# Altium schematic sheet main_Schematic.SchDoc(main_Schematic)
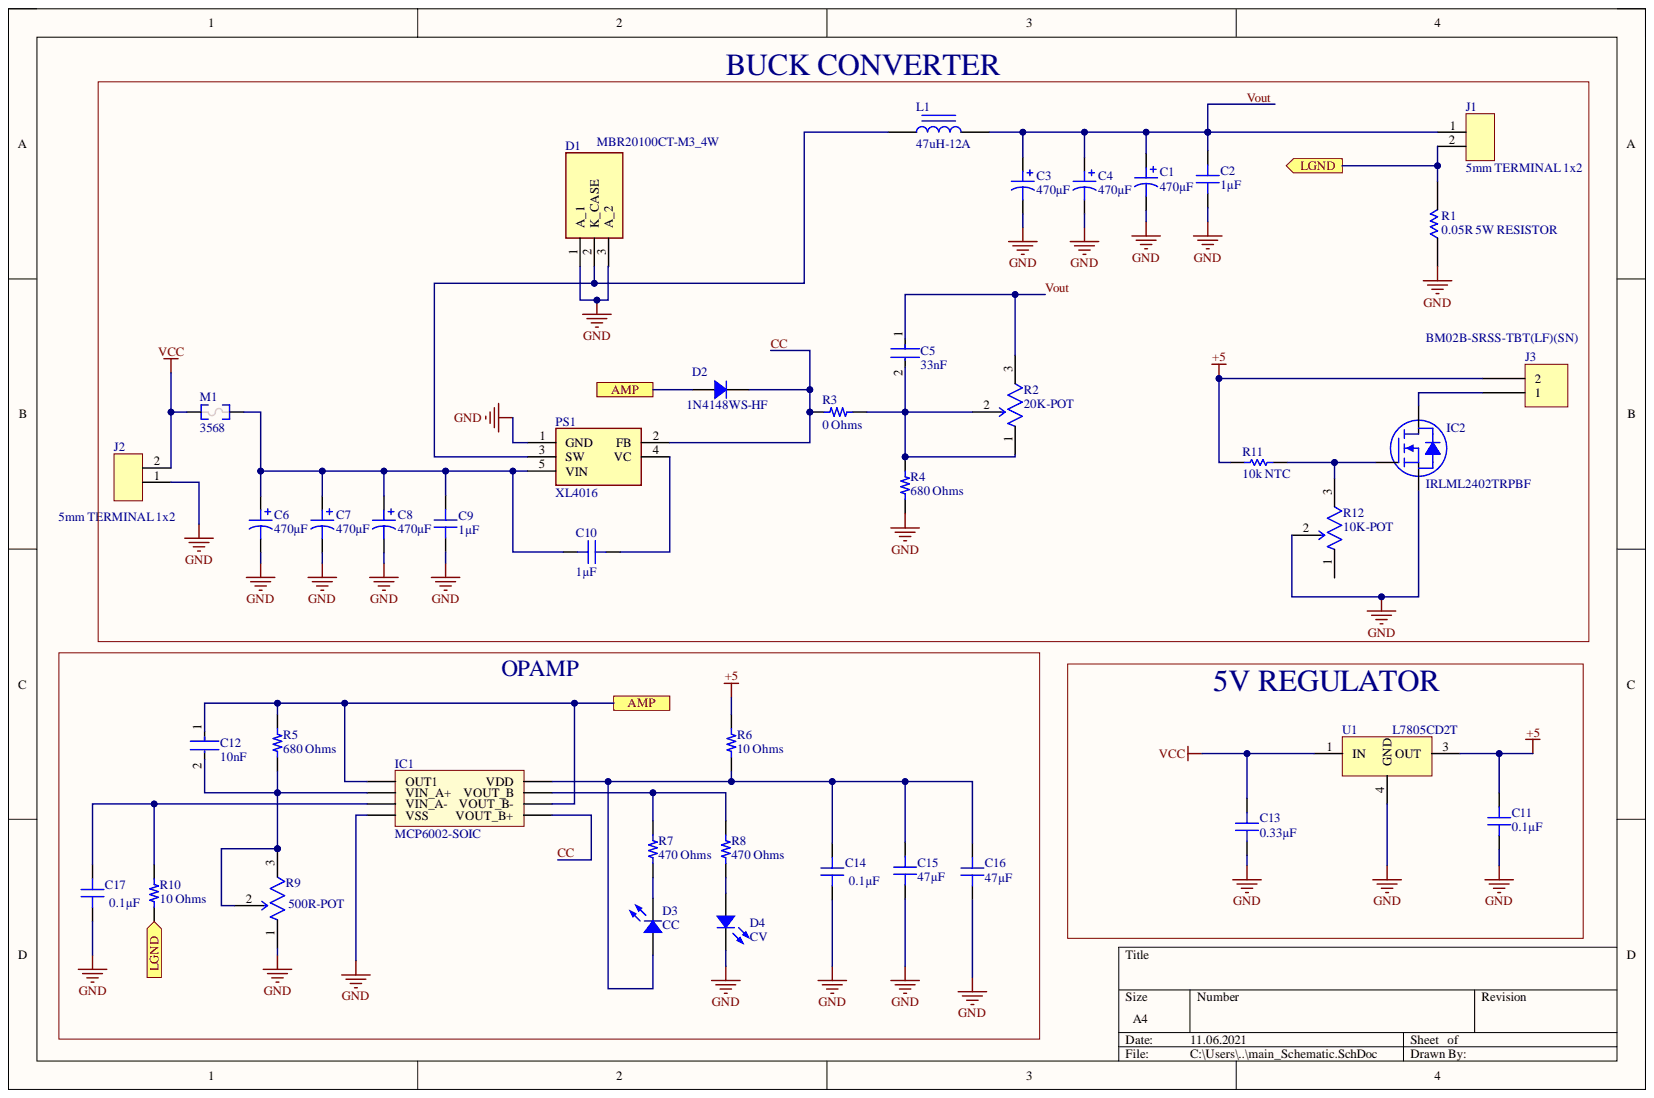
[diagram p0: main_Schematic.SchDoc]

## Parts
C1  470µF  [CAP TH ALUM ELEC D10.00mm S5.00mm H21.50mm]
C2  1µF  [CAP 1206_3216 - 1.2MM]
C3  470µF  [CAP TH ALUM ELEC D10.00mm S5.00mm H21.50mm]
C4  470µF  [CAP TH ALUM ELEC D10.00mm S5.00mm H21.50mm]
C5  33nF  [FP-C1206C-EB-MFG]
C6  470µF  [CAP TH ALUM ELEC D10.00mm S5.00mm H21.50mm]
C7  470µF  [CAP TH ALUM ELEC D10.00mm S5.00mm H21.50mm]
C8  470µF  [CAP TH ALUM ELEC D10.00mm S5.00mm H21.50mm]
C9  1µF  [CAP 1206_3216 - 1.2MM]
C10  1µF  [CAP 1206_3216 - 1.2MM]
C11  0.1µF  [CAP 1206_3216 - 1.0MM]
C12  10nF  [FP-C1206C-EB-MFG]
C13  0.33µF  [CAP 1206_3216 - 1.8MM]
C14  0.1µF  [CAP 1206_3216 - 1.0MM]
C15  47µF  [CAP 1206_3216 - 1.8MM]
C16  47µF  [CAP 1206_3216 - 1.8MM]
C17  0.1µF  [CAP 1206_3216 - 1.0MM]
D1  MBR20100CT-M3_4W  [TO255P470X1010X1989-3P]
D2  1N4148WS-HF  [SOD323]
D3  CC  [LED 1206_3216 RED]
D4  CV  [LED 1206_3216 GREEN]
IC1  MCP6002-SOIC  [SOIC127P600X175-8N]
IC2  IRLML2402TRPBF  [INFINEON SOT-23-3]
J1  5mm TERMINAL 1x2  [5mm TERMINAL 1x2]
J2  5mm TERMINAL 1x2  [5mm TERMINAL 1x2]
J3  BM02B-SRSS-TBT(LF)(SN)  [JST BM02B-SRSS-TB]
L1  47uH-12A  [47uH-12A]
M1  3568  [KEYSTONE 3568]
PS1  XL4016  [XL4016]
R1  0.05R 5W RESISTOR  [0.1R 5W RESISTOR]
R2  20K-POT  [FP-3296W-MFG]
R3  0 Ohms  [RES 1206_3216]
R4  680 Ohms  [RES 1206_3216]
R5  680 Ohms  [RES 1206_3216]
R6  10 Ohms  [RES 1206_3216]
R7  470 Ohms  [RES 1206_3216]
R8  470 Ohms  [RES 1206_3216]
R9  500R-POT  [FP-3296W-MFG]
R10  10 Ohms  [RES 1206_3216]
R11  10k NTC  [RESC3216X180X46NL20T20]
R12  10K-POT  [FP-3296W-MFG]
U1  L7805CD2T  [DPAK228P1085X240-3N]

## Ports
AMP, LGND

## Nets
+5: C11.2 J3.2 R6.2 R11.1 U1.3
GND: C1.2 C2.1 C3.2 C4.2 C6.2 C7.2 C8.2 C9.1 C11.1 C13.1 C14.1 C15.1 C16.1 C17.1 D1.1 D1.3 D4.2 IC1.4(VSS) IC2.2 J2.1 PS1.1(GND) R1.1 R4.1 R9.1 R12.2 U1.4
VCC: C13.2 J2.2 M1.1 U1.1
AMP: C12.1 D2.1 IC1.1(OUT1) IC1.6(VOUT_B-) R5.2 ; port AMP
CC: D2.2 IC1.5(VOUT_B+) PS1.2(FB) R3.2
LGND: J1.2 R1.2 R10.1 ; port LGND
Vout: C1.1 C2.2 C3.1 C4.1 C5.1 J1.1 L1.2 R2.3
NetC5_2: C5.2 R2.1 R2.2 R3.1 R4.2
NetC6_1: C6.1 C7.1 C8.1 C9.2 C10.1 M1.2 PS1.5(VIN)
NetC10_2: C10.2 PS1.4(VC)
NetC12_2: C12.2 IC1.2(VIN_A+) R5.1 R9.2 R9.3
NetC14_2: C14.2 C15.2 C16.2 D3.1 IC1.8(VDD) R6.1
NetC17_2: C17.2 IC1.3(VIN_A-) R10.2
NetD1_2: D1.2 L1.1 PS1.3(SW)
NetD3_2: D3.2 R7.1
NetD4_1: D4.1 R8.1
NetJ3_1: IC2.3 J3.1
NetR7_2: IC1.7(VOUT_B) R7.2 R8.2
NetR11_2: IC2.1 R11.2 R12.3

Not connected: R12 1

## Library data of the parts
C1 C3 C4 C6-C8 = ESMG500ELL471MJ20S: CAP ALUM 470UF 20% 50V RADIAL; Manufacturer: United Chemi-Con; Database Table Name: Capacitors - Aluminum - Electrolytic TH; Lifetime @ Temp.: 2000 Hrs @ 85°C; Polarization: Polar; Ripple Current @ High Frequency: 1.1325A @ 100kHz; Ripple Current @ Low Frequency: 755mA @ 120Hz; Applications: General Purpose; Capacitance: 470µF; Component Kind: Standard; ComponentLink1Description: Datasheet; ComponentLink1URL: http://www.chemi-con.co.jp/cgi-bin/CAT_DB/SEARCH/cat_db_al.cgi?e=e&j=p&pdfname=smg; ComponentLink2Description: DigiKey Link; ComponentLink2URL: http://digikey.com/product-detail/en/united-chemi-con/ESMG500ELL471MJ20S/565-1113-ND/755629; Device Package: Radial, Can; Height - Seated (Max): 0.846" (21.50mm); LastUpdated: 2.09.2020 01:31:34; Lead Spacing: 0.197" (5.00mm); Library Name: Celestial Altium Library - altium_library.DbLib; Lifecycle Status: Active; Minimum Order: 1; Mounting Type: Through Hole; Operating Temperature: -40°C ~ 85°C; Package / Case: Radial, Can; Packaging: Bulk; Part Number: ESMG500ELL471MJ20S; Part Status: Active; PartId: 12556; Price: 0.77; Series: SMG; Size / Dimension: 0.394" Dia (10.00mm); Supplier 1: DigiKey; Supplier Part Number 1: 565-1113-ND; Tolerance: ±20%; Value: 470µF; Voltage - Rated: 50V
C2 C9 C10 = C1206C105M5RACTU: CAP CER 1UF 50V X7R 1206; Manufacturer: KEMET; Database Table Name: Capacitors - Ceramic - 1206; Tolerance: ±20%; Applications: Bypass, Decoupling; Capacitance: 1µF; Component Kind: Standard; ComponentLink1Description: Datasheet; ComponentLink1URL: https://api.kemet.com/component-edge/download/datasheet/C1206C105M5RACTU.pdf; ComponentLink2Description: DigiKey Link; ComponentLink2URL: http://digikey.com/product-detail/en/kemet/C1206C105M5RACTU/399-8151-1-ND/3471874; Device Package: 1206 (3216 Metric); LastUpdated: 2.09.2020 01:05:10; Library Name: Celestial Altium Library - altium_library.DbLib; Lifecycle Status: Active; Minimum Order: 1; Mounting Type: Surface Mount, MLCC; Operating Temperature: -55°C ~ 125°C; Package / Case: 1206 (3216 Metric); Packaging: TapeAndReel; Part Number: C1206C105M5RACTU; Part Status: Active; PartId: 18886; Price: 0.07; Series: SMD Comm X7R; Size / Dimension: 0.126" L x 0.063" W (3.20mm x 1.60mm); Supplier 1: DigiKey; Supplier Part Number 1: 399-8151-1-ND; Temperature Coefficient: X7R; Thickness (Max): 0.043" (1.10mm); Value: 1µF; Voltage - Rated: 50V
C5 = CMP-03020-013789-1: Multilayer Ceramic Capacitor, C Series, 0.033 - F, - 10%, X7r, 50 V, 1206 [3216 Metric]; Manufacturer: KEMET; Manufacturer Part Number: C1206C333K5RACTU; Automotive Grade: Grade 0; Capacitance: 33,000 pF; Temperature Coefficient: 15%; Tolerance: 0.1; Value: 33,000 pF; Voltage Rating: 50 V; Automotive: Yes; Category: Cap; Component Kind: Standard; ComponentLink2Description: Datasheet; ComponentLink2URL: https://content.kemet.com/datasheets/KEM_C1007_X8R_ULTRA_150C_SMD.pdf; Device Class L1: Passive Components; Device Class L2: Capacitors; Device Class L3: Ceramic Capacitors; Digikey Description: Multilayer Ceramic Capacitor, C Series, 0.033 - F, - 10%, X7r, 50 V, 1206 [3216 Metric]; Height: 0.88mm; Lead Free: Yes; Library Name: Altium Content Vault; Material: Ceramic; Mouser Description: Multilayer Ceramic Capacitor, C Series, 0.033 - F, - 10%, X7r, 50 V, 1206 [3216 Metric]; Octopart: https://octopart.com/c1206c333k5ractu-kemet-125953; Package: C1206C-EB; RoHS: TRUE; Temperature: -55°C to +150°C; Temperature Characteristic: X7R; Temperature Range High: +150°C; Temperature Range Low: -55°C; Ipc Land Pattern Name: CAPC320160X78
C11 = CC1206KRX7R7BB104: CAP CER 0.1UF 16V X7R 1206; Manufacturer: Yageo; Database Table Name: Capacitors - Ceramic - 1206; Tolerance: ±10%; Applications: General Purpose; Capacitance: 0.1µF; Component Kind: Standard; ComponentLink1Description: Datasheet; ComponentLink1URL: https://www.yageo.com/upload/media/product/productsearch/datasheet/mlcc/UPY-GPHC_X7R_6.3V-to-50V_18.pdf; ComponentLink2Description: DigiKey Link; ComponentLink2URL: http://digikey.com/product-detail/en/yageo/CC1206KRX7R7BB104/311-4482-1-ND/8025571; Device Package: 1206 (3216 Metric); LastUpdated: 2.09.2020 01:04:53; Library Name: Celestial Altium Library - altium_library.DbLib; Lifecycle Status: Active; Minimum Order: 1; Mounting Type: Surface Mount, MLCC; Operating Temperature: -55°C ~ 125°C; Package / Case: 1206 (3216 Metric); Packaging: TapeAndReel; Part Number: CC1206KRX7R7BB104; Part Status: Active; PartId: 21447; Price: 0.03; Series: CC; Size / Dimension: 0.126" L x 0.063" W (3.20mm x 1.60mm); Supplier 1: DigiKey; Supplier Part Number 1: 311-4482-1-ND; Temperature Coefficient: X7R; Thickness (Max): 0.037" (0.95mm); Value: 0.1µF; Voltage - Rated: 16V
C12 = CMP-2000-06008-2: CAP CER 10000PF 50V X7R 1206; Manufacturer: KEMET; Manufacturer Part Number: C1206C103K5RACTU; Automotive Grade: Grade 0; Capacitance: 10,000 pF; Material: Ceramic; Temperature Coefficient: 15%; Tolerance: 0.1; Value: 10,000 pF; Automotive: Yes; Category: Cap; Component Kind: Standard; ComponentLink2Description: Datasheet; ComponentLink2URL: https://content.kemet.com/datasheets/KEM_C1007_X8R_ULTRA_150C_SMD.pdf; Device Class L1: Passive Components; Device Class L2: Capacitors; Device Class L3: Ceramic Capacitors; Digikey Description: CAP CER 10000PF 50V X7R 1206; Height: 0.88mm; Lead Free: Yes; Library Name: Altium Content Vault; Mouser Description: Multilayer Ceramic Capacitors MLCC - SMD/SMT 50volts 10000pF X7R 10%; Octopart: https://octopart.com/c1206c103k5ractu-kemet-130245; Package: C1206C-EB; RoHS: TRUE; Temperature: -55°C to +150°C; Temperature Characteristic: X7R; Temperature Range High: +150°C; Temperature Range Low: -55°C; Voltage Rating: 50 V; Ipc Land Pattern Name: CAPC320160X78
C13 = CL31B334KBHWPNE: CAP CER 0.33UF 50V X7R 1206; Manufacturer: Samsung Electro-Mechanics; Database Table Name: Capacitors - Ceramic - 1206; Tolerance: ±10%; Applications: Automotive; Capacitance: 0.33µF; Component Kind: Standard; ComponentLink1Description: Datasheet; ComponentLink1URL: http://www.samsungsem.com/kr/support/product-search/mlcc/CL31B334KBHWPNE.jsp; ComponentLink2Description: DigiKey Link; ComponentLink2URL: http://digikey.com/product-detail/en/samsung-electro-mechanics/CL31B334KBHWPNE/1276-6674-1-ND/5961533; Device Package: 1206 (3216 Metric); Features: Open Mode; LastUpdated: 2.09.2020 01:05:22; Library Name: Celestial Altium Library - altium_library.DbLib; Lifecycle Status: Active; Minimum Order: 1; Mounting Type: Surface Mount, MLCC; Operating Temperature: -55°C ~ 125°C; Package / Case: 1206 (3216 Metric); Packaging: TapeAndReel; Part Number: CL31B334KBHWPNE; Part Status: Active; PartId: 22736; Price: 0.08; Ratings: AEC-Q200; Series: CL; Size / Dimension: 0.126" L x 0.063" W (3.20mm x 1.60mm); Supplier 1: DigiKey; Supplier Part Number 1: 1276-6674-1-ND; Temperature Coefficient: X7R; Thickness (Max): 0.071" (1.80mm); Value: 0.33µF; Voltage - Rated: 50V
C14 C17 = C1206C104K8RAC7800: CAP CER 0.1UF 10V X7R 1206; Manufacturer: KEMET; Database Table Name: Capacitors - Ceramic - 1206; Tolerance: ±10%; Applications: Bypass, Decoupling; Capacitance: 0.1µF; Component Kind: Standard; ComponentLink1Description: Datasheet; ComponentLink1URL: https://api.kemet.com/component-edge/download/datasheet/C1206C104K8RACTU.pdf; ComponentLink2Description: DigiKey Link; ComponentLink2URL: http://digikey.com/product-detail/en/kemet/C1206C104K8RAC7800/399-15539-1-ND/7386937; Device Package: 1206 (3216 Metric); LastUpdated: 2.09.2020 01:05:04; Library Name: Celestial Altium Library - altium_library.DbLib; Lifecycle Status: Active; Minimum Order: 1; Mounting Type: Surface Mount, MLCC; Operating Temperature: -55°C ~ 125°C; Package / Case: 1206 (3216 Metric); Packaging: TapeAndReel; Part Number: C1206C104K8RAC7800; Part Status: Active; PartId: 19429; Price: 0.10; Series: SMD Comm X7R; Size / Dimension: 0.126" L x 0.063" W (3.20mm x 1.60mm); Supplier 1: DigiKey; Supplier Part Number 1: 399-15539-1-ND; Temperature Coefficient: X7R; Thickness (Max): 0.035" (0.88mm); Value: 0.1µF; Voltage - Rated: 10V
C15 C16 = CL31A476MPHNNNE: CAP CER 47UF 10V X5R 1206; Manufacturer: Samsung Electro-Mechanics; Database Table Name: Capacitors - Ceramic - 1206; Tolerance: ±20%; Applications: General Purpose; Capacitance: 47µF; Component Kind: Standard; ComponentLink1Description: Datasheet; ComponentLink1URL: http://www.samsungsem.com/kr/support/product-search/mlcc/CL31A476MPHNNNE.jsp; ComponentLink2Description: DigiKey Link; ComponentLink2URL: http://digikey.com/product-detail/en/samsung-electro-mechanics/CL31A476MPHNNNE/1276-3063-1-ND/3891149; Device Package: 1206 (3216 Metric); LastUpdated: 2.09.2020 01:05:28; Library Name: Celestial Altium Library - altium_library.DbLib; Lifecycle Status: Active; Minimum Order: 1; Mounting Type: Surface Mount, MLCC; Operating Temperature: -55°C ~ 85°C; Package / Case: 1206 (3216 Metric); Packaging: TapeAndReel; Part Number: CL31A476MPHNNNE; Part Status: Active; PartId: 22191; Price: 0.16; Series: CL; Size / Dimension: 0.126" L x 0.063" W (3.20mm x 1.60mm); Supplier 1: DigiKey; Supplier Part Number 1: 1276-3063-1-ND; Temperature Coefficient: X5R; Thickness (Max): 0.071" (1.80mm); Value: 47µF; Voltage - Rated: 10V
D1 = MBR20100CT-M3_4W: Schottky Diode; Manufacturer_Name: Vishay; Manufacturer_Part_Number: MBR20100CT-M3/4W; Arrow Part Number: MBR20100CT-M3/4W; Arrow Price/Stock: https://www.arrow.com/en/products/mbr20100ct-m34w/vishay; Component Kind: Standard; Datasheet Link: https://www.vishay.com/docs/89192/mbr20100.pdf; Height: 4.7mm; Mouser Part Number: 78-MBR20100CT-M3/4W; Mouser Price/Stock: https://www.mouser.co.uk/ProductDetail/Vishay-General-Semiconductor/MBR20100CT-M3-4W?qs=QA6fHpkHtKt1A8Yyuwe6pA%3D%3D
D2 = 1N4148WS-HF: DIODE SWITCHING 100V 150MA 200MW; Manufacturer: Comchip Technology; Capacitance @ Vr, F: 2pF @ 0V, 1MHz; Current - Reverse Leakage @ Vr: 1µA @ 75V; Database Table Name: Diodes - Rectifiers - Single; Diode Type: Standard; Reverse Recovery Time (trr): 4ns; Speed: Fast Recovery =< 500ns, > 200mA (Io); Voltage - DC Reverse (Vr) (Max): 75V; Voltage - Forward (Vf) (Max) @ If: 1.25V @ 150mA; Component Kind: Standard; ComponentLink2Description: DigiKey Link; ComponentLink2URL: http://digikey.com/product-detail/en/comchip-technology/1N4148WS-HF/641-1N4148WS-HFCT-ND/12326327; Current - Average Rectified (Io): 150mA; LastUpdated: 30.08.2020 21:07:00; Library Name: Celestial Altium Library - altium_library.DbLib; Lifecycle Status: Active; Minimum Order: 1; Mounting Type: Surface Mount; Operating Temperature - Junction: 125°C; Package / Case: SC-76, SOD-323; Packaging: Unknown; Part Number: 1N4148WS-HF; PartId: 3368; Price: 0.02; Supplier 1: DigiKey; Supplier Device Package: SOD-323; Supplier Part Number 1: 641-1N4148WS-HFCT-ND
D3 = CMD11-21VRC/TR8: LED RED CLEAR 1206 SMD; Manufacturer: Visual Communications Company - VCC; Configuration: Standard; Current - Test: 20mA; Database Table Name: LED - Indication; Lens Color: 1.50mm; Lens Size: 1.10mm Dia; Lens Style: Round with Flat Top; Millicandela Rating: 6mcd; Viewing Angle: 20°; Voltage - Forward (Vf) (Typ): 2V; Wavelength - Peak: 640nm; Color: Red; Component Kind: Standard; ComponentLink1Description: Datasheet; ComponentLink1URL: https://vcclite.com/wp-content/uploads/wpallimport/files/files/CMD11-21%20SMT%20LEDs%201206%20Package%20Size.pdf; ComponentLink2Description: DigiKey Link; ComponentLink2URL: http://digikey.com/product-detail/en/visual-communications-company-vcc/CMD11-21VRC-TR8/L62001CT-ND/254910; Device Package: 1206 (3216 Metric); Height (Max): 1.50mm; LastUpdated: 2.09.2020 01:21:09; Lens Transparency: Clear; Library Name: Celestial Altium Library - altium_library.DbLib; Lifecycle Status: Active; Minimum Order: 1; Mounting Type: Surface Mount; Package / Case: 1206 (3216 Metric); Packaging: TapeAndReel; Part Number: CMD11-21VRC/TR8; Part Status: Active; PartId: 184; Price: 0.12; Series: -; Size / Dimension: 3.00mm L x 1.50mm W; Supplier 1: DigiKey; Supplier Device Package: 1206; Supplier Part Number 1: L62001CT-ND; Value: Red
D4 = XZDG55W-3: LED GREEN CLEAR CHIP SMD; Manufacturer: SunLED; Configuration: Standard; Current - Test: 20mA; Database Table Name: LED - Indication; Lens Color: Colorless; Lens Size: 1.60mm Dia; Lens Style: Round with Domed Top; Millicandela Rating: 2290mcd; Viewing Angle: 35°; Voltage - Forward (Vf) (Typ): 3.3V; Wavelength - Dominant: 525nm; Wavelength - Peak: 515nm; Color: Green; Component Kind: Standard; ComponentLink1Description: Datasheet; ComponentLink1URL: https://www.sunledusa.com/products/spec/XZDG55W-3.pdf; ComponentLink2Description: DigiKey Link; ComponentLink2URL: http://digikey.com/product-detail/en/sunled/XZDG55W-3/1497-1127-1-ND/4745593; Device Package: 1206 (3216 Metric); Height (Max): 1.80mm; LastUpdated: 2.09.2020 01:20:47; Lens Transparency: Clear; Library Name: Celestial Altium Library - altium_library.DbLib; Lifecycle Status: Active; Minimum Order: 1; Mounting Type: Surface Mount; Package / Case: 1206 (3216 Metric); Packaging: TapeAndReel; Part Number: XZDG55W-3; Part Status: Active; PartId: 525; Price: 0.18; Series: -; Size / Dimension: 3.20mm L x 1.60mm W; Supplier 1: DigiKey; Supplier Device Package: Chip LED; Supplier Part Number 1: 1497-1127-1-ND; Value: Green
IC1 = MCP6002-SOIC: MCP6002-SOIC OPAMP; Component Kind: Standard; Library Name: Schlib1.SchLib
IC2 = IRLML2402TRPBF: MOSFET N-CH 20V 1.2A SOT-23; Manufacturer: Infineon Technologies; Current - Continuous Drain (Id) @ 25°C: 1.2A (Ta); Database Table Name: MOSFET - Single - N-Ch; Drive Voltage (Max Rds On,  Min Rds On): 2.7V, 4.5V; FET Type: N-Channel; Gate Charge (Qg) (Max) @ Vgs: 3.9nC @ 4.5V; Power Dissipation (Max): 540mW (Ta); Rds On (Max) @ Id, Vgs: 250mOhm @ 930mA, 4.5V; Vgs (Max): ±12V; Vgs(th) (Max) @ Id: 700mV @ 250µA; Input Capacitance (Ciss) (Max) @ Vds: 110pF @ 15V; Component Kind: Standard; ComponentLink1Description: Datasheet; ComponentLink1URL: https://www.infineon.com/dgdl/irlml2402pbf.pdf?fileId=5546d462533600a401535664e5ef25fa; ComponentLink2Description: DigiKey Link; ComponentLink2URL: http://digikey.com/product-detail/en/infineon-technologies/IRLML2402TRPBF/IRLML2402PBFCT-ND/812508; Drain to Source Voltage (Vdss): 20V; LastUpdated: 2.09.2020 01:25:05; Library Name: Celestial Altium Library - altium_library.DbLib; Lifecycle Status: Active; Minimum Order: 1; Mounting Type: Surface Mount; Operating Temperature: -55°C ~ 150°C (TJ); Package / Case: TO-236-3, SC-59, SOT-23-3; Packaging: TapeAndReel; Part Number: IRLML2402TRPBF; Part Status: Active; Price: 0.10; Series: HEXFET®; Supplier 1: DigiKey; Supplier Device Package: Micro3™/SOT-23; Supplier Part Number 1: IRLML2402PBFCT-ND; Technology: MOSFET (Metal Oxide)
J3 = BM02B-SRSS-TBT(LF)(SN): CONN HEADER SMD 2POS 1MM; Manufacturer: JST Sales America Inc.; Current Rating (Amps): 0.7A; Database Table Name: Connectors - Rectangular Headers Male Pins; Material Flammability Rating: UL94 V-0; Number of Positions: 2; Number of Positions Loaded: All; Pitch - Mating: 0.039" (1.00mm); Shrouding: Shrouded - 4 Wall; Style: Board to Cable/Wire; Voltage Rating: 50V; Component Kind: Standard; ComponentLink1Description: Datasheet; ComponentLink1URL: http://www.jst-mfg.com/product/pdf/eng/eSR.pdf; ComponentLink2Description: DigiKey Link; ComponentLink2URL: http://digikey.com/product-detail/en/jst-sales-america-inc/BM02B-SRSS-TBT-LF-SN/455-2955-1-ND/5725846; Connector Type: Header; Contact Finish - Mating: Tin; Contact Finish - Post: Tin; Contact Material: Copper Alloy; Contact Shape: Square; Contact Type: Male Pin; Fastening Type: Push-Pull; Features: Pick and Place, Solder Retention; LastUpdated: 12.01.2020 22:11:16; Library Name: Celestial Altium Library - altium_library.DbLib; Lifecycle Status: Active; Minimum Order: 1; Mounting Type: Surface Mount; Number of Rows: 1; Operating Temperature: -25°C ~ 85°C; Packaging: TapeAndReel; Part Number: BM02B-SRSS-TBT(LF)(SN); Part Status: Active; PartId: 13; Price: 0.31; Series: SR; Supplier 1: DigiKey; Supplier Part Number 1: 455-2955-1-ND; Termination: Solder; Insulation Color: Natural; Insulation Height: 0.167" (4.25mm); Insulation Material: Polyamide (PA), Nylon
L1 = 47uH-12A: 47uH-12A Power Inductor; Component Kind: Standard; Library Name: Schlib1.SchLib
M1 = 3568: FUSE HOLDER BLADE 500V 30A PCB; Manufacturer: Keystone Electronics; Database Table Name: Fuses - Fuseholders; For Use With/Related Products: MINI ATC, MINI ATO; Fuse Size: 0.43" L x 0.15" W x 0.347" H (10.92mm x 3.81mm x 8.81mm); Number of Circuits: 1; Component Kind: Standard; ComponentLink1Description: Datasheet; ComponentLink1URL: http://keyelco.com/userAssets/file/M65p42.pdf; ComponentLink2Description: DigiKey Link; ComponentLink2URL: http://digikey.com/product-detail/en/keystone-electronics/3568/36-3568-ND/2137306; Contact Finish: Tin; Contact Material: Brass; Current Rating (Amps): 30A; Fuse Type: Blade; Fuseholder Type: Holder; LastUpdated: 5.04.2020 19:02:49; Library Name: Celestial Altium Library - altium_library.DbLib; Lifecycle Status: Active; Minimum Order: 1; Mounting Type: Through Hole; Orientation: Vertical; Packaging: Unknown; Part Number: 3568; Part Status: Active; Price: 1.03; Series: MINI® 297; Supplier 1: DigiKey; Supplier Part Number 1: 36-3568-ND; Termination Style: PC Pin; Voltage: 500V
PS1 = XL4016: Power Supply; Manufacturer_Name: XLSEMI; Component Kind: Standard; Datasheet Link: http://www.xlsemi.com/datasheet/xl4016%20datasheet.pdf; Height: 15.49mm; Library Name: SamacSys.SchLib; Manufacturer_Part_Number: XL4016
R1 = 0.1R 5W RESISTOR: 0.1R 5W RESISTOR STONE; Component Kind: Standard; Library Name: Schlib1.SchLib
R2 R9 R12 = CMP-07234-000024-1: TRIMMER 10K OHM 0.5W PC PIN TOP; Max Power Dissipation: 500mW; Number of Turns: 25; Resistance: 10kR; Temperature Coefficient: 100ppm/°C; Tolerance: 10%; Component Kind: Standard; Composition: Cermet; Contact Plating: Tin; Depth: 4.83mm; Height: 0.395inch; Length: 9.53mm; Library Name: Altium Content Vault; Max Operating Temperature: 125°C; Military Standard: Not; Min Operating Temperature: -55°C; Mount: Through Hole; Package Quantity: 1; Packaging: Tape and Reel; Power Rating: 500mW; Radiation Hardening: No; REACH SVHC: No SVHC; Taper: Linear; Termination: Pin; Weight: 0.03oz; Width: 0.19inch
R3 = RC1206JR-070RL: RES SMD 0 OHM JUMPER 1/4W 1206; Manufacturer: Yageo; Database Table Name: Resistors - Surface Mount - 1206; Power (Watts): 0.25W, 1/4W; Component Kind: Standard; ComponentLink1Description: Datasheet; ComponentLink1URL: https://www.yageo.com/upload/media/product/productsearch/datasheet/rchip/PYu-RC_Group_51_RoHS_L_10.pdf; ComponentLink2Description: DigiKey Link; ComponentLink2URL: http://digikey.com/product-detail/en/yageo/RC1206JR-070RL/311-0.0ERCT-ND/732131; Composition: Thick Film; Device Package: 1206 (3216 Metric); Features: Moisture Resistant; Height - Seated (Max): 0.026" (0.65mm); LastUpdated: 2.09.2020 01:12:40; Library Name: Celestial Altium Library - altium_library.DbLib; Lifecycle Status: Active; Minimum Order: 1; Number of Terminations: 2; Operating Temperature: -55°C ~ 155°C; Package / Case: 1206 (3216 Metric); Packaging: TapeAndReel; Part Number: RC1206JR-070RL; Part Status: Active; PartId: 39273; Price: 0.01; Resistance: 0 Ohms; Series: RC; Size / Dimension: 0.122" L x 0.063" W (3.10mm x 1.60mm); Supplier 1: DigiKey; Supplier Device Package: 1206; Supplier Part Number 1: 311-0.0ERCT-ND; Tolerance: Jumper; Value: 0 Ohms
R4 R5 = CR1206-JW-681ELF: RES SMD 680 OHM 5% 1/4W 1206; Manufacturer: Bourns Inc.; Database Table Name: Resistors - Surface Mount - 1206; Power (Watts): 0.25W, 1/4W; Temperature Coefficient: ±200ppm/°C; Component Kind: Standard; ComponentLink1Description: Datasheet; ComponentLink1URL: https://www.bourns.com/docs/Product-Datasheets/CHPREZTR.pdf; ComponentLink2Description: DigiKey Link; ComponentLink2URL: http://digikey.com/product-detail/en/bourns-inc/CR1206-JW-681ELF/CR1206-JW-681ELF-ND/3785655; Composition: Thick Film; Device Package: 1206 (3216 Metric); Features: Moisture Resistant; LastUpdated: 2.09.2020 01:12:22; Library Name: Celestial Altium Library - altium_library.DbLib; Lifecycle Status: Active; Minimum Order: 5000; Number of Terminations: 2; Operating Temperature: -55°C ~ 155°C; Package / Case: 1206 (3216 Metric); Packaging: TapeAndReel; Part Number: CR1206-JW-681ELF; PartId: 56895; Price: 0.01; Resistance: 680 Ohms; Series: CR1206; Supplier 1: DigiKey; Supplier Device Package: 1206; Supplier Part Number 1: CR1206-JW-681ELF-ND; Tolerance: ±5%; Value: 680 Ohms
R6 R10 = CRCW120610R0JNEB: RES SMD 10 OHM 5% 1/4W 1206; Manufacturer: Vishay Dale; Database Table Name: Resistors - Surface Mount - 1206; Power (Watts): 0.25W, 1/4W; Temperature Coefficient: ±200ppm/°C; Component Kind: Standard; ComponentLink1Description: Datasheet; ComponentLink1URL: http://www.vishay.com/docs/20035/dcrcwe3.pdf; ComponentLink2Description: DigiKey Link; ComponentLink2URL: http://digikey.com/product-detail/en/vishay-dale/CRCW120610R0JNEB/541-CRCW120610R0JNEBCT-ND/11501025; Composition: Thick Film; Device Package: 1206 (3216 Metric); Features: Automotive AEC-Q200; LastUpdated: 2.09.2020 01:12:22; Library Name: Celestial Altium Library - altium_library.DbLib; Lifecycle Status: Active; Minimum Order: 1; Number of Terminations: 2; Operating Temperature: -55°C ~ 155°C; Package / Case: 1206 (3216 Metric); Packaging: TapeAndReel; Part Number: CRCW120610R0JNEB; PartId: 56973; Price: 0.01; Ratings: AEC-Q200; Resistance: 10 Ohms; Series: CRCW; Supplier 1: DigiKey; Supplier Device Package: 1206; Supplier Part Number 1: 541-CRCW120610R0JNEBCT-ND; Tolerance: ±5%; Value: 10 Ohms
R7 R8 = CRCW1206470RJNEAIF: RES SMD 470 OHM 5% 1/4W 1206; Manufacturer: Vishay Dale; Database Table Name: Resistors - Surface Mount - 1206; Power (Watts): 0.25W, 1/4W; Temperature Coefficient: ±200ppm/°C; Component Kind: Standard; ComponentLink1Description: Datasheet; ComponentLink1URL: http://www.vishay.com/docs/20024/dcrcwife3.pdf; ComponentLink2Description: DigiKey Link; ComponentLink2URL: http://digikey.com/product-detail/en/vishay-dale/CRCW1206470RJNEAIF/541-CRCW1206470RJNEAIFCT-ND/11500710; Composition: Thick Film; Device Package: 1206 (3216 Metric); Features: Automotive AEC-Q200, Pulse Withstanding; LastUpdated: 2.09.2020 01:12:23; Library Name: Celestial Altium Library - altium_library.DbLib; Lifecycle Status: Active; Minimum Order: 1; Number of Terminations: 2; Operating Temperature: -55°C ~ 155°C; Package / Case: 1206 (3216 Metric); Packaging: TapeAndReel; Part Number: CRCW1206470RJNEAIF; PartId: 57037; Price: 0.10; Ratings: AEC-Q200; Resistance: 470 Ohms; Series: CRCW-IF; Supplier 1: DigiKey; Supplier Device Package: 1206; Supplier Part Number 1: 541-CRCW1206470RJNEAIFCT-ND; Tolerance: ±5%; Value: 470 Ohms
R11 = CMP-2003-04854-1: Manufacturer: Vishay Dale; Part Number: NTHS1206N02N1002JE; Component Kind: Standard; Library Name: Altium Content Vault
U1 = L7805CD2T: L7805CD2T-35V- 5V-1.5A REGULATOR; Component Kind: Standard; Library Name: Schlib1.SchLib
J1 J2 = 5mm TERMINAL 1x2: Component Kind: Standard; Library Name: Schlib1.SchLib
